# Altium schematic sheet SCH_7410_10_006.SchDoc(SCH_7410_10_006)
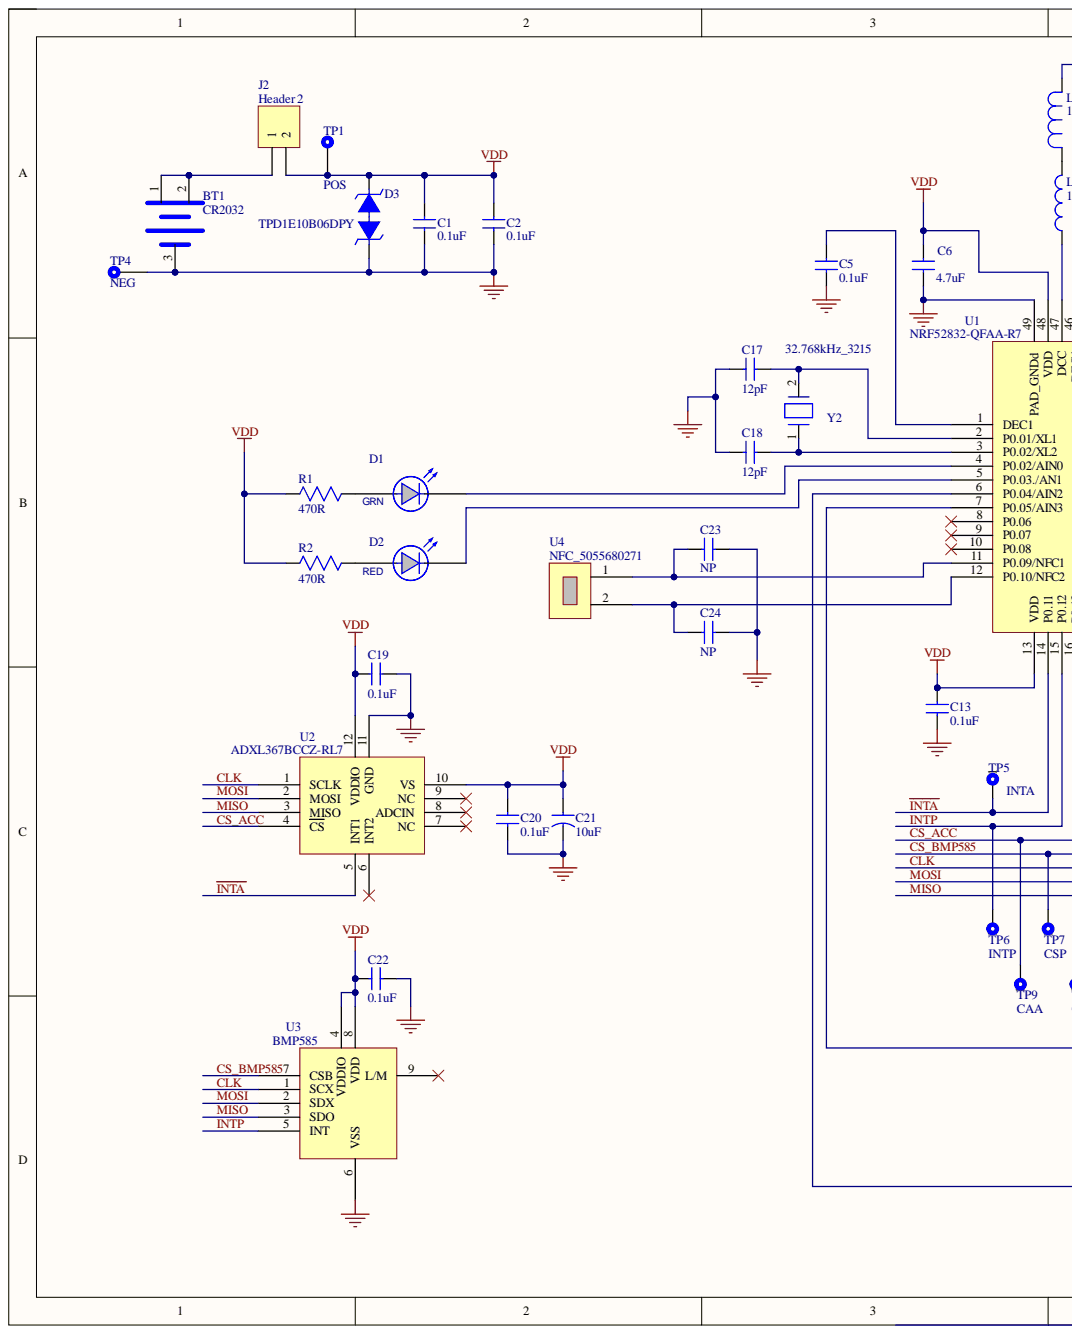
[diagram p0: SCH_7410_10_006.SchDoc, left]
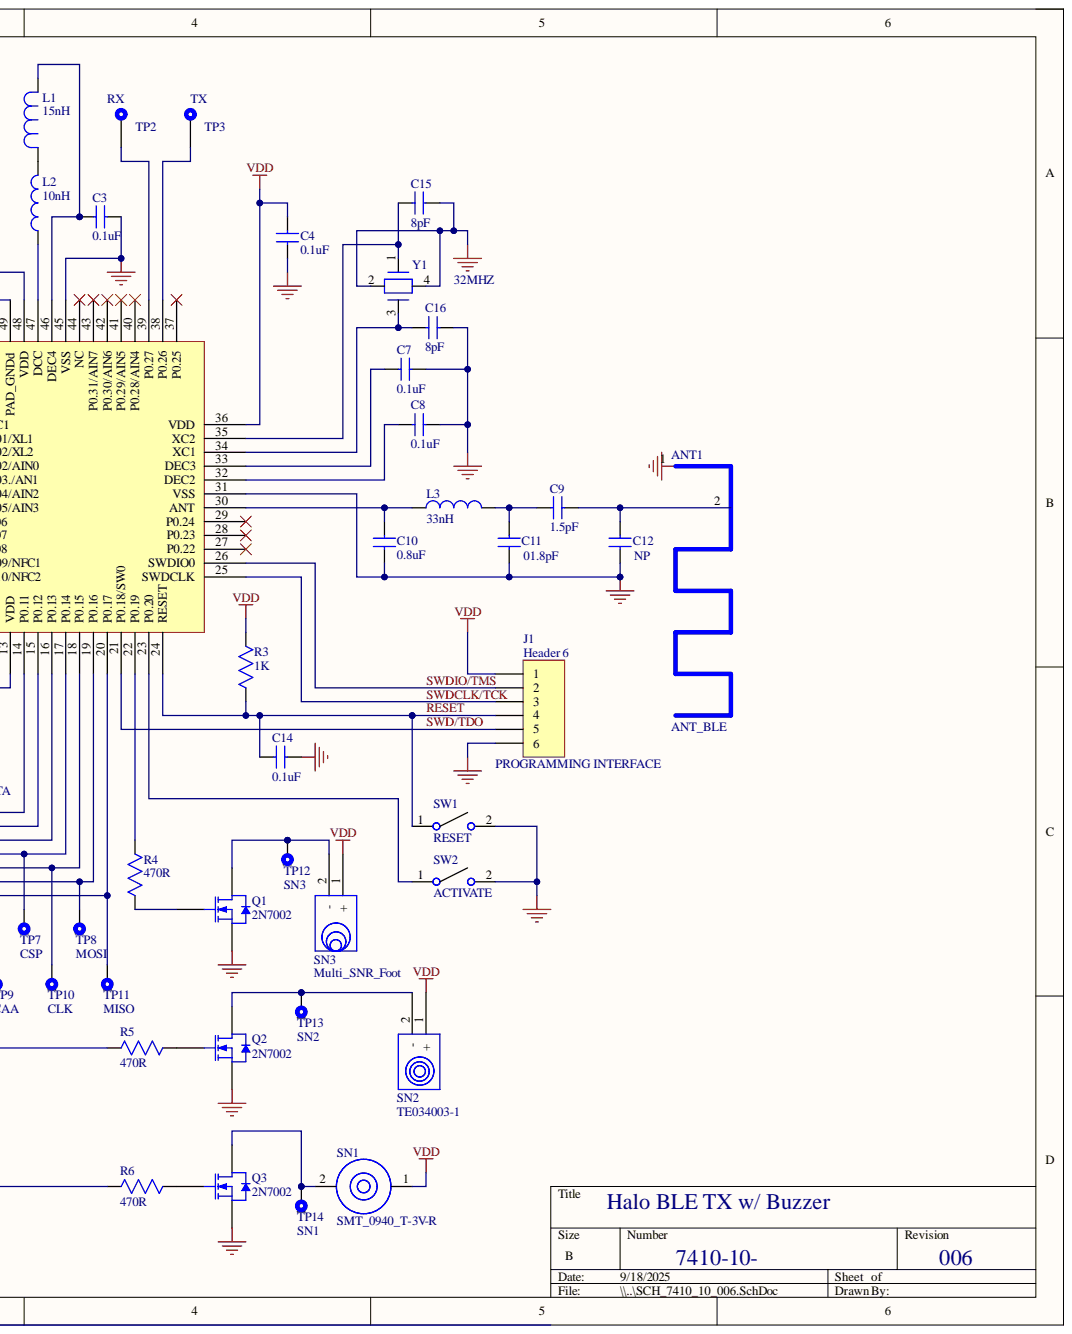
[diagram p1: SCH_7410_10_006.SchDoc, right]

## Parts
ANT1  ANT_BLE  [ANT_BLE]
BT1  CR2032  [CR2032_Holder]
C1  0.1uF  [Cap0603]
C2  0.1uF  [Cap0603]
C3  0.1uF  [Cap0603]
C4  0.1uF  [Cap0603]
C5  0.1uF  [Cap0603]
C6  4.7uF  [CAP_1210_22UF]
C7  0.1uF  [Cap0603]
C8  0.1uF  [Cap0603]
C9  1.5pF  [Cap0603]
C10  0.8uF  [Cap0603]
C11  01.8pF  [Cap0603]
C12  NP  [Cap0603]
C13  0.1uF  [Cap0603]
C14  0.1uF  [Cap0603]
C15  8pF  [Cap0603]
C16  8pF  [Cap0603]
C17  12pF  [Cap0603]
C18  12pF  [Cap0603]
C19  0.1uF  [Cap0603]
C20  0.1uF  [Cap0603]
C21  10uF  [Chip2PinSM127P600-8N_TANT_0603]
C22  0.1uF  [Cap0603]
C23  NP  [Cap0603]
C24  NP  [Cap0603]
D1  LED0603_G  [LED]  (value not drawn)
D2  LED0603_R  [LED]  (value not drawn)
D3  TPD1E10B06DPY  [Ind_0402]
J1  Header 6  [HDR1X6]
J2  Header 2  [HDR1X2]
L1  15nH  [Ind_0402]
L2  10nH  [Inductor0603]
L3  33nH  [Inductor0603]
Q1  2N7002  [SOT-23]
Q2  2N7002  [SOT-23]
Q3  2N7002  [SOT-23]
R1  470R  [RES0603X04N]
R2  470R  [RES0603X04N]
R3  1K  [RES0603X04N]
R4  470R  [RES0603X04N]
R5  470R  [RES0603X04N]
R6  470R  [RES0603X04N]
SN1  SMT_0940_T-3V-R  [0940-T-3V-3V-R]
SN2  TE034003-1  [TE034003-1]
SN3  Multi_SNR_Foot  [SND_Multi_Size]
SW1  RESET  [SW-1_Push]
SW2  ACTIVATE  [SW-1_Push]
TP1  POS  [TEST_POINT_1MM]
TP2  RX  [TEST_POINT_1MM]
TP3  TX  [TEST_POINT_1MM]
TP4  NEG  [TEST_POINT_1MM]
TP5  INTA  [TP_SMALL]
TP6  INTP  [TP_SMALL]
TP7  CSP  [TP_SMALL]
TP8  MOSI  [TP_SMALL]
TP9  CAA  [TP_SMALL]
TP10  CLK  [TP_SMALL]
TP11  MISO  [TP_SMALL]
TP12  SN3  [TP_SMALL]
TP13  SN2  [TP_SMALL]
TP14  SN1  [TP_SMALL]
U1  NRF52832-QFAA-R7  [QFN48_HS-49N]
U2  ADXL367BCCZ-RL7  [ADXL367_Foot]
U3  BMP585  [BMP585]
U4  NFC_5055680271  [NFC_5055680271]
Y1  32MHZ  [XTAL_2.5X2MM_80MHZ]
Y2  32.768kHz_3215  [XTAT_3215]

## Nets
GND: ANT1.1 BT1.3 C1.2 C2.2 C3.2 C4.2 C5.2 C6.2 C7.2 C8.2 C10.2 C11.2 C12.2 C13.2 C14.2 C15.2 C16.2 C17.1 C18.1 C19.2 C20.2 C21.2 C22.2 C23.1 C24.1 D3.2 J1.6 Q1.2 Q2.2 Q3.2 SW1.2 SW2.2 TP4.1 U1.31(VSS) U1.45(VSS) U1.49(PAD_GNDd) U2.11(GND) U3.6(VSS) Y1.2 Y1.4
VDD: C1.1 C2.1 C4.1 C6.1 C13.1 C19.1 C20.1 C21.1 C22.1 D3.1 J1.1 J2.2 R1.1 R2.1 R3.2 SN1.1 SN2.1 SN3.1 TP1.1 U1.13(VDD) U1.36(VDD) U1.48(VDD) U2.10(VS) U2.12(VDDIO) U3.4(VDDIO) U3.8(VDD)
CLK: TP10.1 U1.18(P0.15) U2.1(SCLK) U3.1(SCX)
CS_ACC: TP9.1 U1.16(P0.13) U2.4(CS)
CS_BMP585: TP7.1 U1.17(P0.14) U3.7(CSB)
~{INTA}: TP5.1 U1.14(P0.11) U2.5(INT1)
INTP: TP6.1 U1.15(P0.12) U3.5(INT)
MISO: TP11.1 U1.20(P0.17) U2.3(MISO) U3.3(SDO)
MOSI: TP8.1 U1.19(P0.16) U2.2(MOSI) U3.2(SDX)
RESET: C14.1 J1.4 R3.1 SW1.1 U1.24(RESET)
SWD/TDO: J1.5 U1.21(P0.18/SW0)
SWDCLK/TCK: J1.3 U1.25(SWDCLK)
SWDIO/TMS: J1.2 U1.26(SWDIO0)
NetANT1_2: ANT1.2 C9.2 C12.1
NetBT1_1: BT1.1 BT1.2 J2.1
NetC3_1: C3.1 L1.1 U1.46(DEC4)
NetC5_1: C5.1 U1.1(DEC1)
NetC7_1: C7.1 U1.33(DEC3)
NetC8_1: C8.1 U1.32(DEC2)
NetC9_1: C9.1 C11.1 L3.2
NetC10_1: C10.1 L3.1 U1.30(ANT)
NetC15_1: C15.1 U1.35(XC2) Y1.1
NetC16_1: C16.1 U1.34(XC1) Y1.3
NetC17_2: C17.2 U1.2(P0.01/XL1) Y2.2
NetC18_2: C18.2 U1.3(P0.02/XL2) Y2.1
NetC23_2: C23.2 U1.11(P0.09/NFC1) U4.1
NetC24_2: C24.2 U1.12(P0.10/NFC2) U4.2
NetD1_1: D1.1 U1.4(P0.02/AIN0)
NetD1_2: D1.2 R1.2
NetD2_1: D2.1 U1.5(P0.03./AN1)
NetD2_2: D2.2 R2.2
NetL1_2: L1.2 L2.2
NetL2_1: L2.1 U1.47(DCC)
NetQ1_1: Q1.1 R4.2
NetQ1_3: Q1.3 SN3.2 TP12.1
NetQ2_1: Q2.1 R5.2
NetQ2_3: Q2.3 SN2.2 TP13.1
NetQ3_1: Q3.1 R6.2
NetQ3_3: Q3.3 SN1.2 TP14.1
NetR4_1: R4.1 U1.22(P0.19)
NetR5_1: R5.1 U1.7(P0.05/AIN3)
NetR6_1: R6.1 U1.6(P0.04/AIN2)
NetSW2_1: SW2.1 U1.23(P0.20)
NetTP2_1: TP2.1 U1.39(P0.27)
NetTP3_1: TP3.1 U1.38(P0.26)

Not connected: U1 8(P0.06) 9(P0.07) 10(P0.08) 27(P0.22) 28(P0.23) 29(P0.24) 37(P0.25) 40(P0.28/AIN4) 41(P0.29/AIN5) 42(P0.30/AIN6) 43(P0.31/AIN7) 44(NC); U2 6(INT2) 7(NC) 8(ADCIN) 9(NC); U3 9(L/M)

## Library data of the parts
BT1 = CR2032_Battery_Holder: Component Kind: Standard; Description: CR2032_Battery_Holder; Library Name: SCH_7410_10_001.SchLib
C1-C5 C7-C20 C22-C24 = Cap0603: Component Kind: Standard; Library Name: SCH-8010-01-001.SchLib
C6 = CAP_1210_10uF: Component Kind: Standard; Library Name: SCH-8010-01-001.SchLib
C21 = CAP_TANT_0603: 0603; Component Kind: Standard; Library Name: SCH-8010-01-001.SchLib
J1 = Header 6: Header, 6-Pin; Component Kind: Standard; LatestRevisionDate: 17-Jul-2002; LatestRevisionNote: Re-released for DXP Platform.; Library Name: Miscellaneous Connectors.IntLib; Signal Integrity: Connector; Publisher: Altium Limited
J2 = Header 2: Header, 2-Pin; Component Kind: Standard; LatestRevisionDate: 17-Jul-2002; LatestRevisionNote: Re-released for DXP Platform.; Library Name: Miscellaneous Connectors.IntLib; Signal Integrity: Connector; Publisher: Altium Limited
L1 = Ind_0402: Component Kind: Standard; Description: Ind_0402; Library Name: SCH_7410_10_001.SchLib
L2 L3 = Inductor0603: Component Kind: Standard; Library Name: SCH-8010-01-001.SchLib
R1-R6 = Resistor_0603: Component Kind: Standard; Library Name: SCH-8010-01-001.SchLib
SW1 SW2 = Push_Switch: Component Kind: Standard; Description: Push_Switch; Library Name: SCH_7410_10_001.SchLib
TP1-TP4 = TEST_POINT_ANT: Component Kind: Standard; Library Name: SCH-8010-01-001.SchLib
TP5-TP14 = Test_Point: Component Kind: Standard; Description: Test_Point; Library Name: SCH_7410_10_001.SchLib
U1 = NRF52832: Component Kind: Standard; Description: NRF52832-QFAA-R7; Library Name: SCH_7410_10_001.SchLib
U2 = ADXL367: Component Kind: Standard; Description: ADXL367; Library Name: SCH_7410_10_001.SchLib
Y1 = XTAL_80MHZ: Component Kind: Standard; Library Name: SCH-8010-01-001.SchLib
ANT1 = ANT_BLE: Component Kind: Standard; Library Name: SCH_7410_10_001.SchLib
D1 = LED0603_G: Component Kind: Standard; Library Name: SCH-8010-01-001.SchLib
D2 = LED0603_R: Component Kind: Standard; Library Name: SCH-8010-01-001.SchLib
D3 = TPD1E10B06DPY: Component Kind: Standard; Description: TPD1E10B06DPY; Library Name: SCH_7410_10_001.SchLib
Q1-Q3 = 2N7002: Component Kind: Standard; Description: 2N7002; Library Name: SCH_PP_01_001.SchLib
SN1 = SMT_0940_T-3V-R: Component Kind: Standard; Description: SMT_0940_T-3V-R; Library Name: SCH_7410_10_001.SchLib
SN2 = TE034003-1: Component Kind: Standard; Description: TE034003-1; Library Name: SCH_7410_10_001.SchLib
SN3 = Multi_SNR_Foot: Component Kind: Standard; Description: Multi_SNR_Foot; Library Name: SCH_7410_10_001.SchLib
U3 = BMP585: Component Kind: Standard; Description: BMP585; Library Name: SCH_7410_10_001.SchLib
U4 = NFC_5055680271: Component Kind: Standard; Description: NFC_5055680271; Library Name: SCH_7410_10_001.SchLib
Y2 = 32.768kHz_3215: Component Kind: Standard; Description: 32.768kHz_3215; Library Name: SCH_7410_10_001.SchLib
